# Altium schematic sheet precharge.SchDoc
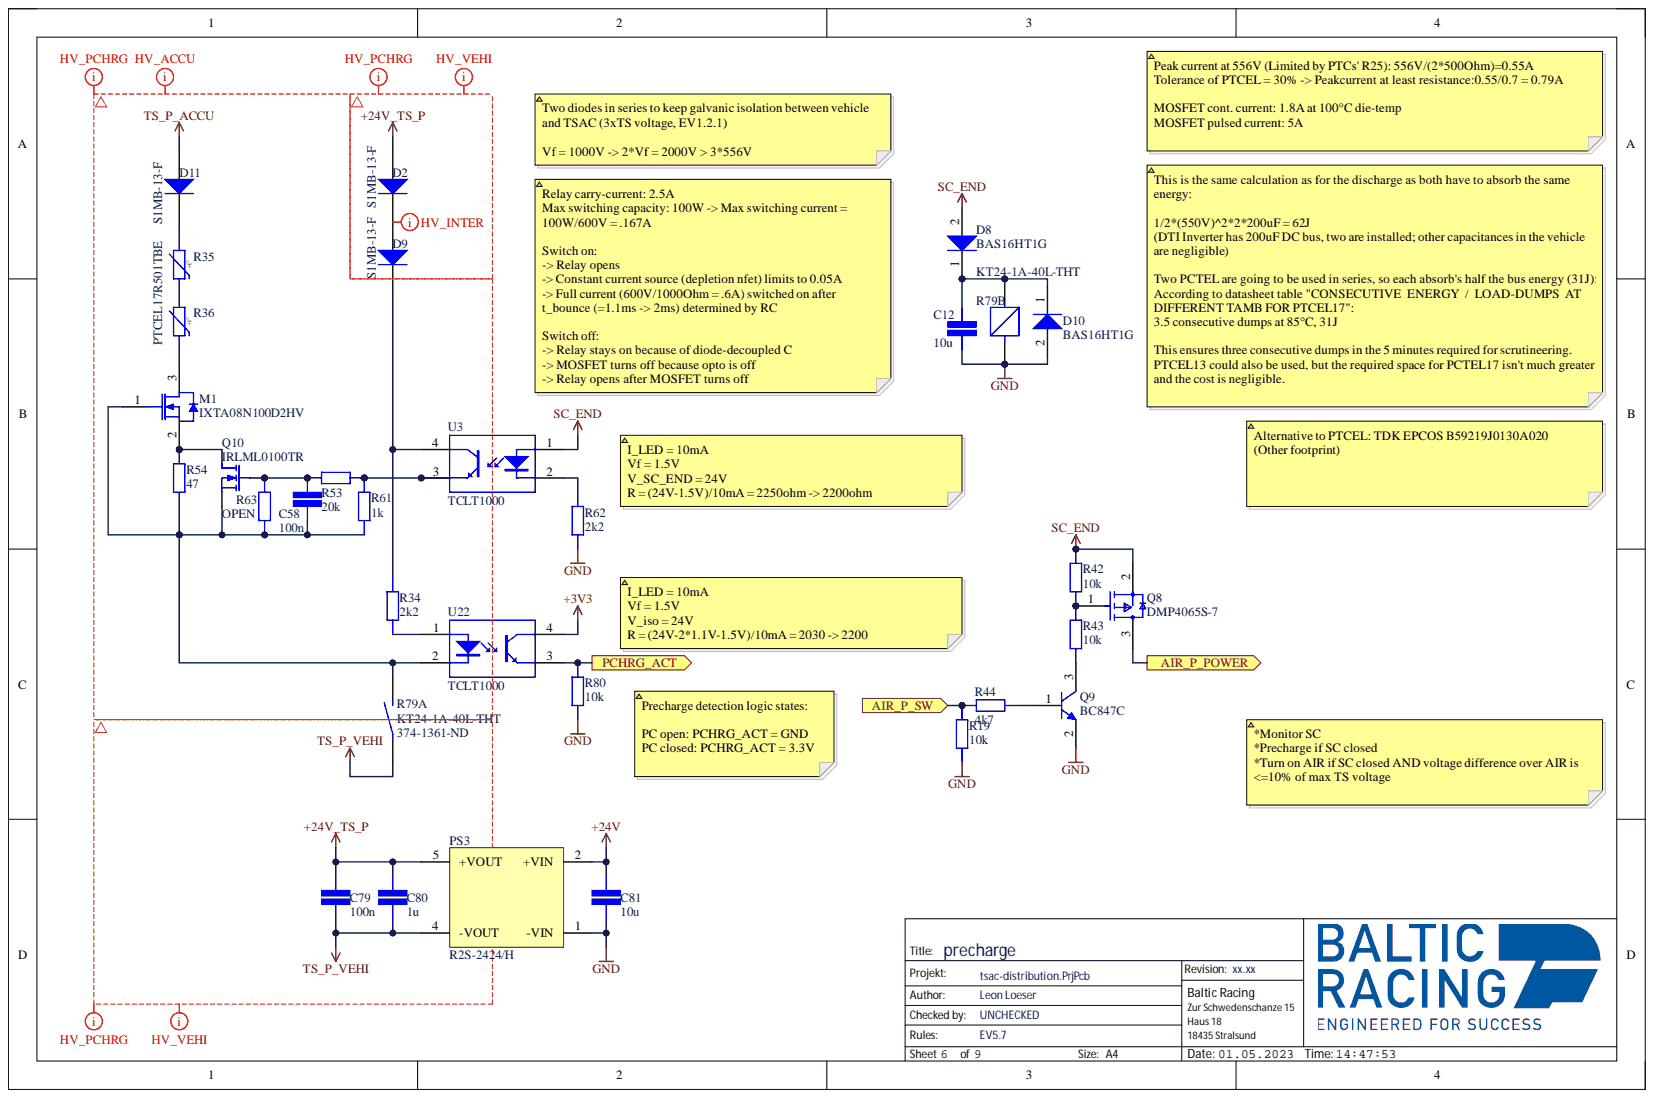
[diagram p0: precharge.SchDoc]

## Parts
C12  value 10u  [CAP 1206/3216]  (hidden comment: SMD Capacitor)
C58  value 100n  [CAP 0603/1608]  (hidden comment: SMD Capacitor)
C79  value 100n  [CAP 0603/1608]  (hidden comment: SMD Capacitor)
C80  value 1u  [CAP 0805/2012]  (hidden comment: SMD Capacitor)
C81  value 10u  [CAP 1206/3216]  (hidden comment: SMD Capacitor)
D2  S1MB-13-F  [DIOD-SMB-2_V]
D8  BAS16HT1G  [ONSC-SOD-323-2-477-02_V]
D9  S1MB-13-F  [DIOD-SMB-2_V]
D10  BAS16HT1G  [ONSC-SOD-323-2-477-02_V]
D11  S1MB-13-F  [DIOD-SMB-2_V]
M1  IXTA08N100D2HV  [IXYS_TO-263HV]
PS3  R2S-2424/H  [RECOM_R2S]
Q8  DMP4065S-7  [FP-SOT23-MFG]
Q9  BC847C  [INF-SOT23_L]
Q10  IRLML0100TR  [SOT23]
R19  value 10k  [STACKPOLE CSR0603]  (hidden comment: SMD Resistor)
R34  value 2k2  [STACKPOLE CSR0603]  (hidden comment: SMD Resistor)
R35  PTCEL17R501TBE  [PTCEL17]
R36  PTCEL17R501TBE  [PTCEL17]
R42  value 10k  [STACKPOLE CSR0603]  (hidden comment: SMD Resistor)
R43  value 10k  [STACKPOLE CSR0603]  (hidden comment: SMD Resistor)
R44  value 4k7  [STACKPOLE CSR0603]  (hidden comment: SMD Resistor)
R53  value 20k  [STACKPOLE CSR0603]  (hidden comment: SMD Resistor)
R54  value 47  [STACKPOLE CSR0603]  (hidden comment: SMD Resistor)
R61  value 1k  [STACKPOLE CSR0603]  (hidden comment: SMD Resistor)
R62  value 2k2  [STACKPOLE CSR0603]  (hidden comment: SMD Resistor)
R63  value OPEN  [STACKPOLE CSR0603]  (hidden comment: SMD Resistor)
R79  KT24-1A-40L-THT  Supplier Part Number 1: 374-1361-ND  [STANDEX_KT_THT]  (parts A,B)
R80  value 10k  [STACKPOLE CSR0603]  (hidden comment: SMD Resistor)
U3  TCLT1000  [VISH-SOP4L-4_V]
U22  TCLT1000  [VISH-SOP4L-4_V]

## Ports
AIR_P_POWER, AIR_P_SW, PCHRG_ACT

## Nets
+3V3: U22.4
+24V: C81.2 PS3.2(+VIN)
+24V_TS_P: C79.1 C80.1 D2.1 PS3.5(+VOUT)
GND: C12.1 C81.1 D10.2 PS3.1(-VIN) Q9.2 R19.2 R62.1 R79.4 R80.1
SC_END: D8.2 Q8.2 R42.1 U3.1
TS_P_ACCU: D11.1
TS_P_VEHI: C79.2 C80.2 PS3.4(-VOUT) R79.1
AIR_P_POWER: Q8.3 ; port AIR_P_POWER
AIR_P_SW: R19.1 R44.2 ; port AIR_P_SW
PCHRG_ACT: R80.2 U22.3 ; port PCHRG_ACT
NetC12_2: C12.2 D8.1 D10.1 R79.3
NetC58_1: C58.1 Q10.1 R53.2 R63.2
NetC58_2: C58.2 M1.1 Q10.2 R54.1 R61.1 R63.1 R79.2 U22.2
NetD2_2: D2.2 D9.1
NetD9_2: D9.2 R34.2 U3.4
NetD11_2: D11.2 R35.1
NetM1_2: M1.2 Q10.3 R54.2
NetM1_3: M1.3 R36.2
NetQ8_1: Q8.1 R42.2 R43.1
NetQ9_1: Q9.1 R44.1
NetQ9_3: Q9.3 R43.2
NetR34_1: R34.1 U22.1
NetR35_2: R35.2 R36.1
NetR53_1: R53.1 R61.2 U3.3
NetR62_2: R62.2 U3.2

## Library data of the parts
C12 C81 = SMD Capacitor: Capacitor with multiple Footprints; Voltage: 25V; Component Kind: Standard; Library Name: Standardbauteile.IntLib
C58 C79 C80 = SMD Capacitor: Capacitor with multiple Footprints; Voltage: 6.3V; Component Kind: Standard; Library Name: Standardbauteile.SchLib
D2 D9 D11 = CMP-2000-07013-1: Surface Mount Glass Passivated Rectifier  , 1 A, 1.1 V, -65 to 150 degC, 2-Pin SMD, RoHS, Tape and Reel; Manufacturer: Diodes Inc; Average Rectified Current-Max: 1A; Forward Voltage: 1.1V; Peak Current-Max: 30A; Reverse Current-Max: 5µA; Reverse Voltage-Max [Vrrm]: 1000V; Component Kind: Standard; Datasheet URL: http://www.diodes.com/_files/datasheets/ds16003.pdf; Datasheet Version: Rev. 24-2, 12/2014; Library Name: Altium Content Vault; Manufacturer URL: http://www.diodes.com/; Mounting Technology: SurfaceMount; Package Description: 2-Pin Surface Mount Device, Body 4.315 x 3.62 mm; Package Reference: SMB-2
D8 D10 = CMP-1054-00068-1: Switching Diode, 2-Pin SOD-323, Pb-Free, Tape and Reel; Manufacturer: On Semiconductor; Configuration: Single; IF Max (A): 0.2; trr Max (ns): 6; Component Kind: Standard; ComponentLink1Description: Manufacturer URL; ComponentLink1URL: http://www.onsemi.com/; ComponentLink2Description: Datasheet; ComponentLink2URL: http://www.onsemi.com/pub_link/Collateral/BAS16HT1-D.PDF; ComponentLink3Description: Package Specification; ComponentLink3URL: http://www.onsemi.com/pub_link/Collateral/477-02.PDF.1; DatasheetVersion: Rev. 11; Library Name: Altium Content Vault; Mounting Technology: Surface Mount; PackageDescription: 2-Pin SOD, Body 1.7 x 1.25 mm; PackageReference: SOD-323-2-477-02; PackageVersion: Rev. H, 03/2007; Packing: Tape and Reel; PartNumber: BAS16HT1G; RoHS: TRUE
M1 = IXTA08N100D2HV: D2 Series - 100V - 1700V N-Channel Depletion Mode Power MOSFETs, TO-263HV, RoHS; Manufacturer: Littelfuse; Category: MOSFETs; Component Kind: Standard; Manufacturer Part Number: IXTA08N100D2HV; Pricing 1: 1=1,74230, 300=2,14500, 500=1,90100, 1000=1,67700, 2000=1,66000, 2500=1,64400, 3000=1,62700, 4000=1,61100, 5000=1,59500, 10000=1,57900, 20000=1,56300 (USD); RoHS: Yes; Stock 1: 30; Supplier 1: Arrow Electronics; Supplier Part Number 1: IXTA08N100D2HV; Library Name: Leon.IntLib
PS3 = R2S-2424/H: 2W DC/DC-Converter 'ECONOLINE' SMD 3kV unreg; V. In: 24; V. Out: 24; mA. Out: 83; Manufacturer: Recom; Efficiency: 85%; Isolation: Isolated; Max Input Voltage: 26.4V; Max Output Current: 83mA; Max Output Voltage: 24V; Min Input Voltage: 21.6V; Number of Outputs: 1; Case/Package: SMD/SMT; Category: Voltage Regulators - Switching; Component Kind: Standard; ComponentLink1Description: Datasheet; ComponentLink1URL: https://datasheet.ciiva.com/6225/r2s-r2d-6225029.pdf?src-supplier=Digi-Key; Depth: 10.7mm; Features: SCP; Height: 8.7mm; Isolation Voltage: 3kV; Lead Free: Lead Free; Length: 12.75mm; Manufacturer Part Number: R2S-2424/H; Max Operating Temperature: 100°C; Max Power Dissipation: 2W; Min Operating Temperature: -40°C; Mount: Surface Mount; Nominal Input Voltage: 24V; Number of Pins: 5; Output Current: 83mA; Output Power: 2W; Output Voltage: 24V; Package Quantity: 39; Packaging: Rail/Tube; Pricing 1: 1=15,71000, 5=15,59400, 10=15,47600, 25=15,23760, 50=14,76140, 100=13,33290, 250=13,09480, 440=12,85673 (USD); RoHS: Yes; RoHS Compliant: Yes; Series: ECONOLINE R2S; Stock 1: 519; Supplier 1: Digi-Key; Supplier Part Number 1: 945-1769-5-ND; Voltage - Output 1: 24V; Weight: 1.4g; Width: 10.7mm; Library Name: Leon.IntLib
Q8 = CMP-12801-000050-1: MOSFET P-CH 40V 2.4A SOT23; Manufacturer: Diodes Inc.; Continuous Drain Current: -2.4A; Depletion Mode: False; Drain To Source Breakdown Voltage: -40V; Drain To Source Resistance: 64mΩ; Gate Charge At Vgs: 12.2nC @ -10V; Gate To Source Voltage: 20V; Input Capacitace At Vds: 587pF @ -20V; Max Forward Diode Voltage: -1.2V; Max Junction Temp: +150°C; Number Of Channels: 1; Power Dissipation: 0.72W; Pulse Drain Current: -20A; Rthja Max: 171°C/W; Standoff Height: 0.013mm; Threshold Vgs Max: -3V; Threshold Vgs Min: -1V; Turn Off Delay Time: 36.3ns; Turn On Delay Time: 3.6ns; Typ Forward Diode Voltage: -0.7V; Automotive: No; Category: Trans; Component Kind: Standard; ComponentLink2Description: Datasheet; ComponentLink2URL: https://www.diodes.com/assets/Datasheets/DMP4065S.pdf; Device Class L1: Discrete Semiconductors; Device Class L2: Transistors; Device Class L3: MOSFETs; Digikey Description: MOSFET P-CH 40V 2.4A SOT23; Drain To Source Voltage: -40V; Footprint Url: https://www.diodes.com/assets/Package-Files/SOT23.pdf; Height: 1.1mm; Ipc Land Pattern Name: SOT92P240X102-3; Lead Free: Yes; Library Name: Altium Content Vault; Manufacturer Part Number: DMP4065S-7; Mouser Description: Trans MOSFET P-CH 40V 2.4A Automotive 3-Pin SOT-23 T/R; Number Of P Channels: 1; Octopart: https://octopart.com/dmp4065s-7-diodes+inc.-57605044; Package: SOT23; RoHS: TRUE; Temperature: -55°C to +150°C; Temperature Range High: +150°C; Temperature Range Low: -55°C
Q9 = CMP-1177-00037-1: NPN Silicon AF Transistor, 45 V VCEO, 100 mA IC, SOT23, Reel, Green; Manufacturer: Infineon; fT (typ): 250Â MHz; Green: TRUE; IBM (max): 200Â mA; IC (max): 100Â mA; ICBO (max): 15Â nA; Ptot (max): 330Â mW; VCBO (max): 50Â V; VCEO (max): 45Â V; VEBO (max): 6Â V; Component Kind: Standard; ComponentLink1Description: Manufacturer URL; ComponentLink1URL: http://www.infineon.com/; ComponentLink2Description: Datasheet; ComponentLink2URL: http://www.infineon.com/dgdl/bc847series_bc848series_bc849series_bc850series.pdf?folderId=db3a304314dca389011541d30fa21656&fileId=db3a304314dca389011541d4630a1657; ComponentLink3Description: Package Specification; ComponentLink3URL: http://www.infineon.com/dgdl/SOT23-Package_Overview.pdf?folderId=db3a30431936bc4b0119532290053c66&fileId=db3a30431936bc4b0119533468123c85; ICM (max): 200Â mA; Library Name: Altium Content Vault; Mounting Technology: Surface Mount; PackageDescription: 3-Pin Small Outline Transistor Package, 1.3 x 2.9 mm Body, 0.95 mm Pitch; PackageReference: SOT23; PackageVersion: Apr-08; Packing: Reel; PartNumber: BC847C; Polarity: NPN
Q10 = MOSFET N: N-Channel MOSFET    RK7002BMT116:  250mA, 60Vds, 20Vgs    IRLML0100TR:  1.6A, 100Vds, 16Vgs; Component Kind: Standard; Library Name: Standardbauteile.IntLib
R19 R34 R42-R44 R53 R54 R61-R63 R80 = SMD Resistor: Resistor with multiple footprints; Component Kind: Standard; Library Name: Standardbauteile.IntLib
R35 R36 = PTCEL17R501TBE: PTCEL Series 1000 V 500 Ohm ±30 % Radial Inrush Current Limiter PTC Thermistor; Manufacturer: Vishay BCcomponents; Tolerance: 30%; Component Kind: Standard; ComponentLink1Description: http://www.vishay.com/docs/29165/ptcel.pdf?src-supplier=Digi-Key; ComponentLink1URL: http://www.vishay.com/docs/29165/ptcel.pdf?src-supplier=Digi-Key; Manufacturer Part Number: PTCEL17R501TBE; Pricing 1: 1=3,37000, 5=3,30000, 10=3,15000, 25=3,00000, 50=2,70000, 100=2,55000, 200=2,46000, 500=2,25000, 1000=2,17000, 10000=2,17000 (USD); RoHS: No; RoHS Compliant: No; Stock 1: 130; Supplier 1: Mouser; Supplier Part Number 1: 594-PTCEL17R501TBE; Library Name: Leon.IntLib
R79 = STANDEX_KT24-1A-40L-THT: Reed Relay, Kt Series, 24 Vdc, 1.8 Kohm, 1 A, 1 Kv, Spst-No Rohs Compliant: Yes; Manufacturer: Standex-Meder Electronics; Coil Current: 13.3mA; Coil Power: 320mW; Coil Resistance: 1.8kR; Coil Type: Non-Latching; Coil Voltage (DC): 24V; Contact Current Rating: 1A; Throw Configuration: SPST; Category: Uncategorized; Component Kind: Standard; ComponentLink1Description: Datasheet; ComponentLink1URL: https://standexelectronics.com/de/ressourcen/technische-bibliothek/technische-informationen/datasheet-kt-series-reed-relay/; Manufacturer Part Number: KT24-1A-40L-THT; Max Operating Temperature: 100°C; Min Operating Temperature: -40°C; Mount: Through Hole; Package Quantity: 15; Packaging: Rail/Tube; Pricing 1: 1=11,88000, 15=11,13533, 30=9,89800, 45=9,40311, 90=8,90822, 240=7,91842, 450=7,42351, 945=6,92860 (USD); REACH SVHC: Unknown; Relay Type: Reed; RoHS: No; RoHS Compliant: No; Supplier 1: Digi-Key; Termination: PC Pin; Library Name: Leon.IntLib
U3 U22 = CMP-2000-07234-1: Optocoupler, Phototransistor Output, VCEO 70 V, -55 to 100 degC, 4-Pin SOP (SOP4L), RoHS, Tape and Reel; Manufacturer: Vishay/Semiconductor; Input Type: DC; Maximum Collector Current: 50mA; Maximum Collector Emitter Saturation Voltage: 300mV; Maximum Collector Emitter Voltage: 70V; Maximum Current Transfer Ratio @ Current: 600%; Maximum Forward Voltage: 1.6V; Maximum Input Current: 60mA; Maximum Power Dissipation: 250mW; Maximum Reverse Voltage: 6V; Minimum Isolation Voltage: 5000Vrms; Number of Channels per Chip: 1; Typical Forward Voltage: 1.25V; Category: TransistorandPhotovoltaicOutputPhotoCouplers; Component Kind: Standard; Datasheet URL: http://www.vishay.com/docs/83515/tclt1000.pdf; Datasheet Version: Rev. 2.9, 12/2015; Library Name: Altium Content Vault; Manufacturer URL: http://www.vishay.com/; Mounting Technology: SurfaceMount; Operating Temperature: -40to100°C; Output Type: DC; Package: 4SOP; Package Description: 4-Pin Small Outline Package Integrated Circuit, Body 4.1 x 7.5 mm, Pitch 2.54 mm; Package Reference: SOP4L; Packaging: Tape&Reel
